# Altium schematic sheet HT-TRS-Interface-Schematic.SchDoc
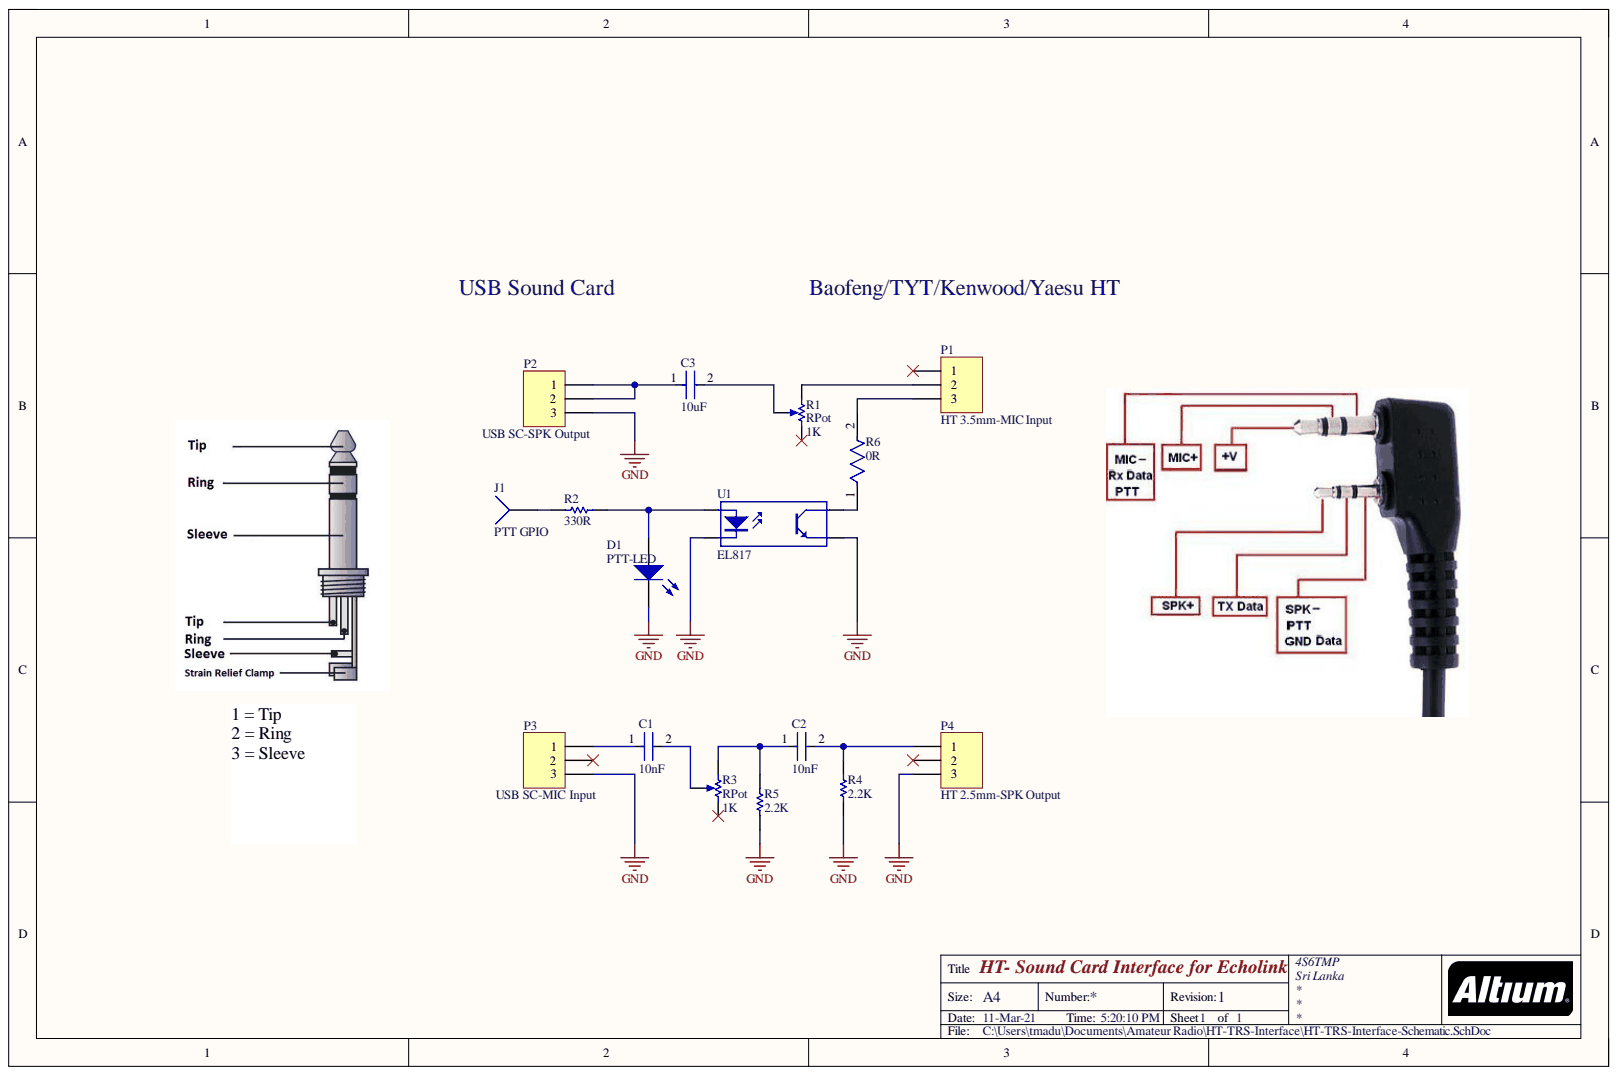
[diagram p0: HT-TRS-Interface-Schematic.SchDoc]

## Parts
C1  10nF  [FP-CC0603-DA-MFG]
C2  10nF  [FP-CC0603-DA-MFG]
C3  10uF  [FP-C1608-MFG]
D1  PTT-LED  [3.2X1.6X1.1]
J1  PTT GPIO  [PIN1]
P1  HT 3.5mm-MIC Input  [MHDR1X3]
P2  USB SC-SPK Output  [MHDR1X3]
P3  USB SC-MIC Input  [MHDR1X3]
P4  HT 2.5mm-SPK Output  [MHDR1X3]
R1  RPot  value 1K  [VR5]
R2  330R  [RESC1608X55X30LL15T15]
R3  RPot  value 1K  [VR5]
R4  2.2K  [RESC1608X55X30ML20T20]
R5  2.2K  [RESC1608X55X30ML20T20]
R6  0R  [FP-ERJ-3GEY0R00V-MFG]
U1  EL817  [DIP-4]

## Nets
GND: D1.2 P2.3 P3.3 P4.3 R4.1 R5.1 U1.2 U1.3
NetC1_1: C1.1 P3.1
NetC1_2: C1.2 R3.3
NetC2_1: C2.1 R3.1 R5.2
NetC2_2: C2.2 P4.1 R4.2
NetC3_1: C3.1 P2.1 P2.2
NetC3_2: C3.2 R1.3
NetD1_1: D1.1 R2.2 U1.1
NetJ1_1: J1.1 R2.1
NetP1_2: P1.2 R1.1
NetP1_3: P1.3 R6.2
NetR6_1: R6.1 U1.4

Not connected: P1 1; P3 2; P4 2; R1 2; R3 2

## Library data of the parts
C1 C2 = CMP-03422-000327-1: CAP CER 10000PF 25V X7R 0603; Manufacturer: YAGEO; Manufacturer Part Number: CC0603KRX7R8BB103; Material: Ceramic; Temperature Coefficient: 15%; Tolerance: 0.1; Automotive: No; Capacitance: 10 nF; Category: Cap; Component Kind: Standard; ComponentLink2Description: Datasheet; ComponentLink2URL: http://www.yageo.com/documents/recent/UPY-GPHC_X7R_6.3V-to-50V_18.pdf; Device Class L1: Passive Components; Device Class L2: Capacitors; Device Class L3: Ceramic Capacitors; Digikey Description: CAP CER 10000PF 25V X7R 0603; Footprint Url: http://www.yageo.com/exep/pages/download/literatures/UPY-C_GEN_24.pdf; Height: 0.9mm; Lead Free: Yes; Library Name: Altium Content Vault; Mouser Description: Multilayer Ceramic Capacitors MLCC - SMD/SMT 10nF 25V X7R 10%; Octopart: https://octopart.com/cc0603krx7r8bb103-yageo-9288195; Package: CC0603-DA; RoHS: TRUE; Temperature: -55°C to +125°C; Temperature Characteristic: X7R; Temperature Range High: +125°C; Temperature Range Low: -55°C; Value: 10 nF; Voltage: 25 V; Voltage Rating: 25 V
C3 = CMP-08246-000019-1: CAP CER 10UF 16V X5R 0603; Manufacturer: TDK Corporation; Manufacturer Part Number: C1608X5R1C106M080AB; Material: Ceramic; Temperature Coefficient: 15%; Tolerance: 20%; Automotive: No; Capacitance: 10uF; Category: Cap; Component Kind: Standard; ComponentLink2Description: Datasheet; ComponentLink2URL: https://upverter.com/datasheet/6fbac97fd59b4a24a005814037d2a36a27db40e9.pdf; Device Class L1: Passive Components; Device Class L2: Capacitors; Device Class L3: Ceramic Capacitors; Digikey Description: CAP CER 10UF 16V X5R 0603; Height: 0.9mm; Ipc Land Pattern Name: CAPC16080X80; Lead Free: Yes; Library Name: Altium Content Vault; Mouser Description: Multilayer Ceramic Capacitors MLCC - SMD/SMT 0603 10uF 16volts X5R +/-20% GP; Octopart: https://octopart.com/c1608x5r1c106m080ab-tdk-26013480; Package: C1608; RoHS: TRUE; Temperature: -55°C to +85°C; Temperature Characteristic: X5R; Temperature Range High: +85°C; Temperature Range Low: -55°C; Value: 10uF; Voltage Rating: 16V
D1 = LED2: Typical RED, GREEN, YELLOW, AMBER GaAs LED; Component Kind: Standard; LatestRevisionDate: 17-Jul-2002; LatestRevisionNote: Re-released for DXP Platform.; Library Name: Miscellaneous Devices.IntLib; PackageDescription: SM LED; 2 Flat Leads; PackageReference: 3.2X1.6X1.1; PackageVersion: Feb-2002; Published: 8-Jun-2000; Simulation: LED2; Publisher: Altium Limited
J1 = Socket: Component Kind: Standard; Description: Socket; LatestRevisionDate: 17-Jul-2002; LatestRevisionNote: Re-released for DXP Platform.; Library Name: Miscellaneous Connectors.IntLib; Signal Integrity: Connector; Publisher: Altium Limited
P1-P4 = MHDR1X3: Header, 3-Pin; Component Kind: Net Tie; LatestRevisionDate: 17-Jul-2002; LatestRevisionNote: Re-released for DXP Platform.; Library Name: Miscellaneous Connectors.IntLib; Signal Integrity: Connector; Publisher: Altium Limited
R1 R3 = RPot: Potentiometer; Set Position: 0.5; Sim Note: Enter the Resistance value and the set point into parameter fields.; Component Kind: Standard; LatestRevisionDate: 17-Jul-2002; LatestRevisionNote: Re-released for DXP Platform.; Library Name: Miscellaneous Devices.IntLib; PackageDescription: Variable Resistor, Thru-Hole; 3 Leads; PackageReference: VR5; Published: 8-Jun-2000; Simulation: POT; Publisher: Altium Limited
R2 = CMP-2000-00435-2: Chip Resistor, 330 Ohm, +/- 1%, 0.1 W, -55 to 155 degC, 0603 (1608 Metric), RoHS, Tape and Reel; Current Rating: 500 mA; Gender: Male; Mating Cycles: 10000; Voltage Rating: 10 V; Component Kind: Standard; ComponentLink1Description: Manufacturer URL; ComponentLink1URL: http://www.panasonic.com/; ComponentLink2Description: Datasheet; ComponentLink2URL: http://industrial.panasonic.com/cdbs/www-data/pdf/RDA0000/AOA0000C86.pdf; Contact Finish: Gold; Contact Material: Copper; Contact Plating: Gold; DatasheetVersion: Apr-15; Height: 1.45 mm; Lead Pitch: 1.1 mm; Length: 13.7 mm; Library Name: Altium Content Vault; Max Operating Temperature: 85 degC; Min Operating Temperature: -25 degC; Mount: Surface Mount; Package Quantity: 1500; PackageDescription: 2-Pin Surface Mount Device, Body 1.6 x 0.85 mm; PackageReference: 0603; Packaging: Tape and Reel; Pins: 8; REACH SVHC: No SVHC; RoHSCompliant: true; Width: 1.45 mm
R4 R5 = CMP-2000-00955-1: Manufacturer: Panasonic; Part Number: ERA3AEB222V; Component Kind: Standard; Library Name: Altium Content Vault
R6 = CMP-2000-00700-2: RES SMD 0 OHM JUMPER 1/10W 0603; Manufacturer: Panasonic; Manufacturer Part Number: ERJ-3GEY0R00V; Automotive Grade: Grade 0; Power Rating: 0.1W; Resistance: 0Ω; Temperature Coefficient: -100/+600ppm/°C; Tolerance: 5%; Value: 0Ω; Voltage Rating: 75V; Automotive: Yes; Category: Res; Component Kind: Standard; ComponentLink2Description: Datasheet; ComponentLink2URL: https://industrial.panasonic.com/cdbs/www-data/pdf/RDA0000/AOA0000C301.pdf; Composition: Thick Film; Device Class L1: Passive Components; Device Class L2: Resistors; Device Class L3: Chip SMD Resistors; Digikey Description: RES SMD 0 OHM JUMPER 1/10W 0603; Footprint Url: https://industrial.panasonic.com/cdbs/www-data/pdf/RDA0000/DMA0000COL17.pdf; Height: 0.55mm; Ipc Land Pattern Name: RESC16080X45; Lead Free: Yes; Library Name: Altium Content Vault; Mouser Description: Resistor, Chip Thick Film, 0 Ohm, 0.1w, Resistor, Chip Thick Film, 0 Ohm, 0.1w, 5%; Octopart: https://octopart.com/erj-3gey0r00v-panasonic-55389238; Package: 0603; RoHS: TRUE; Series: ERJ; Temperature: -55°C to +155°C; Temperature Range High: +155°C; Temperature Range Low: -55°C
U1 = Optoisolator1: 4-Pin Phototransistor Optocoupler; Component Kind: Standard; DatasheetVersion: Nov-2003; LatestRevisionDate: 04-Mar-2005; LatestRevisionNote: Sch pins 3 & 4 Swapped.; Library Name: Miscellaneous Devices.IntLib; PackageReference: DIP-4; Published: 24-Mar-1999; Publisher: Altium Limited
